# Altium schematic sheet Sheet2.SchDoc(Sheet2)
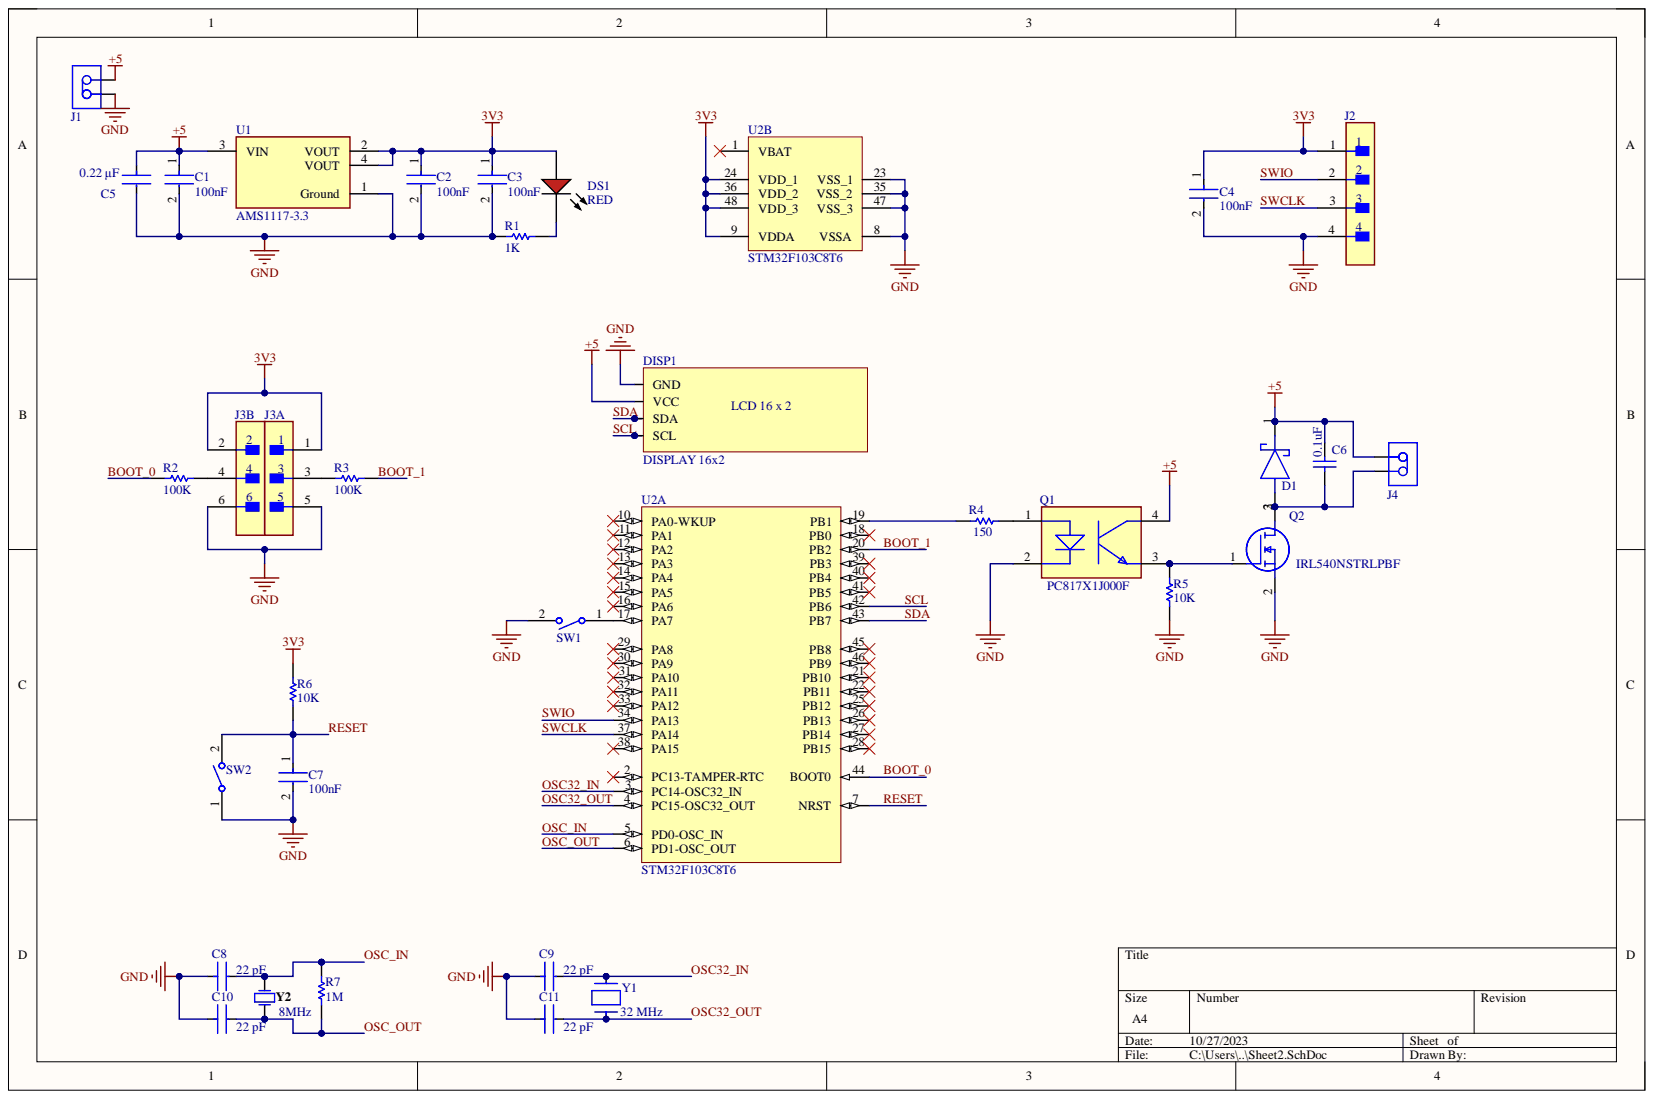
[diagram p0: Sheet2.SchDoc]

## Parts
C1  100nF  [FP-0805-L_2_01_0_2-W_1_25-IPC_B]
C2  100nF  [FP-0805-L_2_01_0_2-W_1_25-IPC_B]
C3  100nF  [FP-0805-L_2_01_0_2-W_1_25-IPC_B]
C4  100nF  [FP-0805-L_2_01_0_2-W_1_25-IPC_B]
C5  value 0.22 μF  Capacitance: 0.22 μF  [FP-C0603C-CF-MFG]  (hidden comment: C0603C224K3RACTU)
C6  0.1uF  [CAPR51-254-508X318X736]
C7  100nF  [FP-0805-L_2_01_0_2-W_1_25-IPC_B]
C8  value 22 pF  [FP-C0603C-CF-MFG]  (hidden comment: C0603C220J5GACTU)
C9  value 22 pF  [FP-C0603C-CF-MFG]  (hidden comment: C0603C220J5GACTU)
C10  value 22 pF  [FP-C0603C-CF-MFG]  (hidden comment: C0603C220J5GACTU)
C11  value 22 pF  [FP-C0603C-CF-MFG]  (hidden comment: C0603C220J5GACTU)
D1  SSB44-E3_52T  [DIOM5436X244N]  (value not drawn)
DISP1  DISPLAY 16x2  [display 16x2]
DS1  RED  [AVAG-HSMX-C190_V]
J1  640456-2  [TECO-640456-2_V]  (value not drawn)
J2  HTSW-104-07-G-S  [FP-HTSW-104-07-G-S-MFG]  (value not drawn)
J3  20021121-00006C4LF  [FP-20021121-00006C4LF-MFG]  (value not drawn)  (parts A,B)
J4  640456-2  [TECO-640456-2_V]  (value not drawn)
Q1  PC817X1J000F  [FP-PC817X1J000F-MFG]
Q2  IRL540NSTRLPBF  [IRF2804STRLPBF]
R1  1K  [RESC1608X55X30LL15T15]
R2  100K  [RESC2013X50X30ML20T20]
R3  100K  [RESC2013X50X30ML20T20]
R4  150  [RESC1608X55X25ML10T15]
R5  10K  [RESC1609X50X30NL8T20]
R6  10K  [RESC1609X50X30NL8T20]
R7  1M  [RESC1609X50X30LL10T20]
SW1  FSMSMTR  [TECO-FSMSMTR_V]  (value not drawn)
SW2  FSMSMTR  [TECO-FSMSMTR_V]  (value not drawn)
U1  AMS1117-3.3  [FP-AMS1117-IPC_C]
U2  STM32F103C8T6  [STM-LQFP48_L]  (parts A,B)
Y1  Operating Frequency: 32 MHz  [FP-ABM7-MFG]  (hidden comment: ABM7-32.000MHZ-D2Y-T)
Y2  Frequency: 8MHz  [FOX-HC49SDLF_V]  (hidden comment: FOXSDLF/080-20)

## Nets
3V3: C2.1 C3.1 C4.1 DS1.1 J2.1 J3.1 J3.2 R6.1 U1.2(VOUT) U1.4(VOUT) U2.9(VDDA) U2.24(VDD_1) U2.36(VDD_2) U2.48(VDD_3)
+5: C1.1 C5.1 C6.2 D1.1 DISP1.2(VCC) J1.1 J4.2 Q1.4 U1.3(VIN)
GND: C1.2 C2.2 C3.2 C4.2 C5.2 C7.2 C8.1 C9.1 C10.1 C11.1 DISP1.1(GND) J1.2 J2.4 J3.5 J3.6 Q1.2 Q2.2 R1.1 R5.2 SW1.2 SW2.1 U1.1(Ground) U2.8(VSSA) U2.23(VSS_1) U2.35(VSS_2) U2.47(VSS_3)
BOOT_0: R2.1 U2.44(BOOT0)
BOOT_1: R3.2 U2.20(PB2)
OSC32_IN: C9.2 U2.3(PC14-OSC32_IN) Y1.2
OSC32_OUT: C11.2 U2.4(PC15-OSC32_OUT) Y1.1
OSC_IN: C8.2 R7.2 U2.5(PD0-OSC_IN) Y2.2
OSC_OUT: C10.2 R7.1 U2.6(PD1-OSC_OUT) Y2.1
RESET: C7.1 R6.2 SW2.2 U2.7(NRST)
SCL: DISP1.4(SCL) U2.42(PB6)
SDA: DISP1.3(SDA) U2.43(PB7)
SWCLK: J2.3 U2.37(PA14)
SWIO: J2.2 U2.34(PA13)
NetC6_1: C6.1 D1.2 J4.1 Q2.3
NetDS1_2: DS1.2 R1.2
NetJ3_3: J3.3 R3.1
NetJ3_4: J3.4 R2.2
NetQ1_1: Q1.1 R4.2
NetQ1_3: Q1.3 Q2.1 R5.1
NetR4_1: R4.1 U2.19(PB1)
NetSW1_1: SW1.1 U2.17(PA7)

Not connected: U2 1(VBAT) 2(PC13-TAMPER-RTC) 10(PA0-WKUP) 11(PA1) 12(PA2) 13(PA3) 14(PA4) 15(PA5) 16(PA6) 18(PB0) 21(PB10) 22(PB11) 25(PB12) 26(PB13) 27(PB14) 28(PB15) 29(PA8) 30(PA9) 31(PA10) 32(PA11) 33(PA12) 38(PA15) 39(PB3) 40(PB4) 41(PB5) 45(PB8) 46(PB9)

## Library data of the parts
C1-C4 C7 = CMP-2007-04174-2: General Purpose Ceramic Capacitor, 0805, 100nF, 10%, X7R, 15%, 100V; Capacitance: 100nF; Case Code (Imperial): 0805; Case Code (Metric): 2012; Case/Package: 0805; Component Kind: Standard; Construction: Flat; Contact Plating: Tin; Depth: 1.25mm; Dielectric: X7R; Dielectric Material: Ceramic; Height: 1.4mm; Lead Free: Lead Free; Length: 2.01mm; Library Name: Altium Content Vault; Max Operating Temperature: 125°C; Min Operating Temperature: -55°C; Mount: Surface Mount; Number of Pins: 2; Package Quantity: 2000; Packaging: Tape and Reel; Radiation Hardening: No; REACH SVHC: No SVHC; RoHS Compliant: Yes; Series: -; Termination: SMD/SMT; Thickness: 0.055inch; Tolerance: 10%; Voltage: 100V; Voltage Rating: 100V; Voltage Rating (DC): 100V; Width: 0.049inch
C5 = CMP-2006-03146-2: CAP CER 0.22UF 25V 10% X7R 0603; Manufacturer: KEMET; Automotive Grade: Grade 1; Material: Ceramic; Temperature Coefficient: 30ppm/°C; Tolerance: 0.1; Automotive: Yes; Category: Cap; Component Kind: Standard; ComponentLink2Description: Datasheet; ComponentLink2URL: https://api.kemet.com/component-edge/download/datasheet/C0603C100J5GACAUTO.pdf; Device Class L1: Passive Components; Device Class L2: Capacitors; Device Class L3: Ceramic Capacitors; Digikey Description: CAP CER 0.22UF 25V 10% X7R 0603; Height: 0.87mm; Lead Free: Yes; Library Name: Altium Content Vault; Manufacturer Part Number: C0603C224K3RACTU; Mouser Description: Multilayer Ceramic Capacitors MLCC - SMD/SMT 25volts 0.22uF X7R 10%; Octopart: https://octopart.com/c0603c224k3ractu-kemet-129141; Package: C0603C-CF; RoHS: TRUE; Temperature: -55°C to +125°C; Temperature Characteristic: X7R; Temperature Range High: +125°C; Temperature Range Low: -55°C; Voltage Rating: 25 V
C6 = CMP-1664-00004-1: Radial Leaded Multilayer Ceramic Capacitor, 0.1 uF, +/- 10%, 50 V, -55 to 125 degC, 2-Pin THD, RoHS, Tape and Reel; Case\Package: SOT-23-5; Dropout Voltage: 260 mV; Max Input Voltage: 8 V; Max Power Dissipation: 250 mW; Output Current: 150 mA; Output Voltage: 5 V; Outputs: 1; Component Kind: Standard; ComponentLink1Description: Manufacturer URL; ComponentLink1URL: http://www.kemet.com/; ComponentLink2Description: Datasheet; ComponentLink2URL: http://www.kemet.com/docfinder?Partnumber=C320C104K5R5TA; DatasheetVersion: Dec-15; Height: 1.3 mm; Length: 3 mm; Library Name: Altium Content Vault; Max Operating Temperature: 85 degC; Min Operating Temperature: -40 degC; Mount: Surface Mount; Package Quantity: 3000; PackageDescription: 2-Pin Through Hole Device, Body 5.08 x 3.18 mm, Pitch 2.54 mm; PackageReference: CAPR51-254-508X318X736; Packaging: Tape and Reel; Pins: 5; Radiation Hardening: No; RoHSCompliant: true; Width: 1.8 mm
C8-C11 = CMP-2006-03119-3: Cap Ceramic 22pF 50V C0G 5% SMD 0603 125°C Paper T/R; Manufacturer: KEMET; Automotive Grade: Grade 1; Temperature Coefficient: 30ppm/°C; Tolerance: 0.05; Automotive: Yes; Capacitance: 22 pF; Category: Cap; Component Kind: Standard; ComponentLink2Description: Datasheet; ComponentLink2URL: https://api.kemet.com/component-edge/download/datasheet/C0603C100J5GACAUTO.pdf; Device Class L1: Passive Components; Device Class L2: Capacitors; Device Class L3: Ceramic Capacitors; Digikey Description: Cap Ceramic 22pF 50V C0G 5% SMD 0603 125°C Paper T/R; Height: 0.87mm; Lead Free: Yes; Library Name: Altium Content Vault; Manufacturer Part Number: C0603C220J5GACTU; Material: Ceramic; Mouser Description: Cap Ceramic 22pF 50V C0G 5% SMD 0603 125°C Paper T/R; Octopart: https://octopart.com/c0603c220j5gactu-kemet-98525; Package: C0603C-CF; RoHS: TRUE; Temperature: -55°C to +125°C; Temperature Characteristic: C0G; Temperature Range High: +125°C; Temperature Range Low: -55°C; Value: 22 pF; Voltage Rating: 50 V
D1 = SSB44-E3_52T: Schottky Diode; Manufacturer_Name: Vishay; Manufacturer_Part_Number: SSB44-E3/52T; Arrow Part Number: SSB44-E3/52T; Arrow Price/Stock: https://www.arrow.com/en/products/ssb44-e352t/vishay?region=nac; Component Kind: Standard; Datasheet Link: https://www.vishay.com/docs/88884/ssb43l.pdf; Height: 2.44mm; Mouser Part Number: 625-SSB44-E3; Mouser Price/Stock: https://www.mouser.co.uk/ProductDetail/Vishay-General-Semiconductor/SSB44-E3-52T?qs=x2jpVgRnAtOp%252BgpElPB95w%3D%3D
DS1 = CMP-1744-00004-1: LED Uni-Color Red, 65 mW, 20 mA, -40 to 85 degC, 2-Pin SMD, RoHS, Tape and Reel; Connector Type: USB; Current Rating: 1 A; Gender: Male; Component Kind: Standard; ComponentLink1Description: Manufacturer URL; ComponentLink1URL: http://www.avagotech.com/; ComponentLink2Description: Datasheet; ComponentLink2URL: http://docs.avagotech.com/docs/AV02-0551EN; ComponentLink3Description: Package Specification; ComponentLink3URL: http://www.fairchildsemi.com/dwg/MA/MA03D.pdf; Contact Material: Copper; Contact Plating: Gold; DatasheetVersion: Mar-12; Height: 2.8 mm; Lead Pitch: 0.65 mm; Length: 7.5 mm; Library Name: Altium Content Vault; Max Operating Temperature: 85 degC; Min Operating Temperature: -30 degC; Mount: Surface Mount; Package Quantity: 2000; PackageDescription: 2-Pin Surface Mount Device, Body 1.6 x 0.8 mm, Height 0.9 mm; PackageReference: HSMX-C190; Packaging: Tape and Reel; Pins: 5; REACH SVHC: No SVHC; RoHSCompliant: true; Width: 5.6 mm
J1 J4 = CMP-2000-05417-1: Male Header, Pitch 2.54 mm, 1 x 2 Position, Height 10.49 mm, Tail Length 3.556 mm, -55 to 105 degC, RoHS, Bulk; Manufacturer: TE Connectivity; Agency Approvals: CSA,UL; Angle: Straight; Brand/Series: MTA-100Series; Color, Housing: White; Connector Type: MTA-100; Contact Centerline Spacing: 0.100    in.; Contact Termination Area Plating: Tin; Current, Rating: 5    A; Material, Contact: CopperAlloy; Material, Housing: Polyester; Mount Angle: Vertical; Mounting Hole Size: 0.04    "; Number of Contacts: 2; Pin Spacing: 0.1    "; Primary Type: InterconnectSystem; Special Features: Pinstrip; Standards: RoHSCompliant,ELVCompliant,UL94V-0; Temperature, Operating: -55to+105    degC; Voltage, Rating: 250    V; Color: White; Component Kind: Standard; Contact Mating Area Plating: Tin; Contact Plating: Tin; Contact Shape: Square; Datasheet URL: https://datasheet.ciiva.com/11746/0900766b80eb7fdd-11746365.pdf; Datasheet Version: Rev. W, 06/2004; Flammability Rating: UL94V-0; Length, Overall: 0.2    "; Length, Pin: 0.14    "; Length, Terminal Post: 0.14    in.; Library Name: Altium Content Vault; Manufacturer URL: http://www.te.com/; Mounting Type: PCMount; Number of Positions: 2; Number of Rows: 1; Size, Post: 0.025    in.; Termination: Solder; Type: PCBPlug
J2 = CMP-02766-001776-1: CONN HEADER VERT 4POS 2.54MM; Gender: Male; Number of Contacts: 4; Component Kind: Standard; Contact Material: Bronze; Contact Plating: Gold; Library Name: Altium Content Vault; Mount: Through Hole; Number of Rows: 1; Packaging: Bulk; Pitch: 2.54mm; Radiation Hardening: No; Reach SVHC Compliant: Yes; RoHS Compliant: Yes; Series: HTSW; Termination: Through Hole
J3 = CMP-15126-126517163-1: 20021121-00006C4LF Conn Unshrouded Header HDR 6 POS 1.27mm Solder ST SMD Automotive T/R; Connector Type: Header, Male Pin; Contact Resistance: 30mΩ; Current Rating: 1A; Gender: Male; Insulation Resistance: 100MΩ; Mating Post Length: 3.05mm; Max Voltage Rating (AC): 125V; Number of Contacts: 6; Stack Height: 3mm; Color: Black; Component Kind: Standard; Contact Material: Copper, Gold; Contact Mating Length: 3.048mm; Contact Plating: Gold; Depth: 3.4mm; Height: 5.05mm; Housing Color: Black; Housing Material: Thermoplastic; Length: 3.81mm; Library Name: Altium Content Vault; Max Operating Temperature: 85°C; Min Operating Temperature: -55°C; Mount: Surface Mount; NexarPartId: 126517163; Number of Pins: 6; Number of Positions: 6; Number of Rows: 2; Orientation: Straight; Pitch: 1.27mm; Radiation Hardening: No; REACH SVHC: No SVHC; RoHS: Compliant; Row Spacing: 1.27mm; Termination: Solder; Voltage Rating: 125V
Q1 = CMP-65434-000003-1: OPTOISOLATOR 5KV TRANS 4DIP; Collector Emitter Breakdown Voltage: 80V; Collector Emitter Saturation Voltage: 200mV; Current Transfer Ratio: 600%; Fall Time: 18000ns; Forward Current: 50mA; Forward Voltage: 1.4V; Input Current: 20mA; Max Power Dissipation: 200mW; Number of Channels: 1; Reverse Breakdown Voltage: 6V; Case/Package: DIP; Collector Emitter Voltage (VCEO): 80V; Component Kind: Standard; Isolation Voltage: 5kV; Library Name: Altium Content Vault; Max Collector Current: 50mA; Max Input Current: 50mA; Max Operating Temperature: 100°C; Min Operating Temperature: -30°C; Mount: Through Hole; Number of Elements: 1; Number of Pins: 4; Output Current per Channel: 50mA; Output Voltage: 80V; Package Quantity: 2000; Packaging: Sleeve; Power Dissipation: 200mW; Radiation Hardening: No; Rise Time: 18000ns
Q2 = IRL540NSTRLPBF: MOSFET (N-Channel); Manufacturer_Name: Infineon; Arrow Part Number: IRL540NSTRLPBF; Arrow Price/Stock: https://www.arrow.com/en/products/irl540nstrlpbf/infineon-technologies-ag?region=nac; Component Kind: Standard; Datasheet Link: https://datasheet.datasheetarchive.com/originals/distributors/Datasheets_SAMA/a976ea931f47dfa9180662a8f907d205.pdf; Height: 4.83mm; Manufacturer_Part_Number: IRL540NSTRLPBF; Mouser Part Number: 942-IRL540NSTRLPBF; Mouser Price/Stock: https://www.mouser.co.uk/ProductDetail/Infineon-Technologies/IRL540NSTRLPBF?qs=9%252BKlkBgLFf2wqPOjL9rZ8Q%3D%3D
R1 = CMP-2000-00180-1: Manufacturer: Panasonic; Part Number: ERJ-3EKF1001V; Component Kind: Standard; Library Name: Altium Content Vault
R2 R3 = CMP-2001-04900-1: Manufacturer: Vishay Dale; Part Number: CRCW0805100KJNEA; Component Kind: Standard; Library Name: Altium Content Vault
R4 = CMP-1659-00057-1: Chip Resistor, 150 Ohm, +/- 1%, 0.1 W, -55 to 155 degC, 0603 (1608 Metric), RoHS, Tape and Reel; Case\Package: Radial; Forward Voltage: 2.2 V; Illumination Colour: Green; Lens Style: Clear; Luminous Intensity: 200 mcd; Viewing Angle: 20 degrees; Colour: Green; Component Kind: Standard; ComponentLink1Description: Manufacturer URL; ComponentLink1URL: http://www.yageo.com/; ComponentLink2Description: Datasheet; ComponentLink2URL: http://www.yageo.com/documents/recent/PYu-RC_Group_51_RoHS_L_04.pdf; DatasheetVersion: Rev. 4, 02/2016; Height: 8.6 mm; Library Name: Altium Content Vault; Mount: Through Hole; Nominal Vf: 2.2 V; Package Quantity: 1000; PackageDescription: 2-Pin Surface Mount Device, Body 1.6 x 0.8 mm; PackageReference: 0603; Packaging: Bulk; RoHSCompliant: true
R5 R6 = CMP-1666-00005-4: Chip Resistor, 10 KOhm, +/- 1%, 100 mW, -55 to 155 degC, 0603 (1608 Metric), RoHS, Tape and Reel; Manufacturer: Vishay Semiconductor; Voltage Rating: 75 V; Component Kind: Standard; ComponentLink1Description: Manufacturer URL; ComponentLink1URL: http://www.vishay.com/; ComponentLink2Description: Datasheet; ComponentLink2URL: https://datasheet.ciiva.com/11809/0900766b80d98afc-11809037.pdf; DatasheetVersion: 06/2012; Height: 0.45 mm; Length: 1.55 mm; Library Name: Altium Content Vault; Max Operating Temperature: 155 degC; Min Operating Temperature: -55 degC; Mounting Technology: Surface Mount; Package Quantity: 5000; PackageDescription: 2-Pin Surface Mount Device, Body 1.575 x 0.85 mm; PackageReference: 0603; Packaging: Tape and Reel; REACH SVHC: Unknown; RoHSCompliant: true
R7 = CMP-2000-03015-1: Manufacturer: Vishay Dale; Part Number: CRCW06031M00FKTA; Component Kind: Standard; Library Name: Altium Content Vault
SW1 SW2 = CMP-2000-07501-1: FSMSM Push Button Switch, 50 mA, -35 to 85 degC, 2-Pin SMD, RoHS, Tape and Reel; Manufacturer: TE Connectivity; Actuator Length: 1.07mm; Actuator Material: Polyamide; Case\/Package: SMD/SMT; Contact Current Rating: 50mA; Contact Resistance: 100mOhm; Electrical Life: 20000Cycles; Illumination Type: Illuminated; Max Voltage Rating (DC): 12V; Operating Force: 180gf; Switch Travel: 0.25mm; Throw Configuration: SPST; Voltage Rating (DC): 24V; Component Kind: Standard; Contact Material: Brass; Contact Plating: Silver; Current Rating: 50mA; Datasheet URL: http://www.te.com/commerce/DocumentDelivery/DDEController?Action=showdoc&DocId=Customer+Drawing%7F1437566-3%7FC2%7Fpdf%7FEnglish%7FENG_CD_1437566-3_C2_1437566_3_1_c.dwg.pdf%7F1437566-4; Datasheet Version: Rev. C2, 08/2014; ELV: Compliant; Lead Free: LeadFree; Library Name: Altium Content Vault; Manufacturer URL: http://www.te.com/; Max Operating Temperature: 85degC; Mechanical Life: 20000Cycles; Min Operating Temperature: -35degC; Mount: SurfaceMount; Mounting Technology: SurfaceMount; Orientation: Straight; Package Description: 2-Pin Surface Mount Device, Body 6 x 3.5 mm, Pitch 9.19 mm; Package Quantity: 1800; Package Reference: FSMSMTR; Packaging: TapeandReel; REACH SVHC: Unknown; RoHSCompliant: true; Termination: GullWing
U1 = CMP-209535-000001-1: LDO Voltage Regulators 1A, 3.3V; Manufacturer: Advanced Monolithic Systems; Dropout Voltage: 1.1V; Max Junction Temp: +125°C; Max Power Dissipation: 1.2W; Max Supply Voltage: 15V; Nominal Supply Current: 5mA; Number Of Outputs: 1; Output Type: Fixed; Standoff Height: 0.64mm; Automotive: No; Category: IC; Component Kind: Standard; ComponentLink2Description: Datasheet; ComponentLink2URL: http://www.advanced-monolithic.com/pdf/ds1117.pdf; Device Class L1: Integrated Circuits (ICs); Device Class L2: Power Management ICs; Device Class L3: Voltage Regulators - Linear; Height: 1.8mm; Ipc Land Pattern Name: SOT229P700X180-4; Lead Free: Yes; Library Name: Altium Content Vault; Manufacturer Part Number: AMS1117-3.3; Octopart: https://octopart.com/ams1117-3.3-advanced+monolithic+systems-25899300; Output Current: 1A; Output Voltage: 3.3V; Package: AMS1117; RoHS: TRUE; Temperature: -40°C to +125°C; Temperature Range High: +125°C; Temperature Range Low: -40°C
U2 = CMP-0237-00215-3: ARM Cortex-M3 32-bit MCU, 64 KB Flash, 20 KB Internal RAM, 37 I/Os, 48-pin LQFP, -40 to 85 degC, Tray; A/D Converter: 2x12-bit (10 Channel); FLASH Size (Prog) (kB): 64; I/Os (High Current): 37; Internal RAM Size (kB): 20; Operating Frequency(F) (Processor speed) (MHz): 72; Supply Voltage(Vcc) min (V): 2; Component Kind: Standard; ComponentLink1Description: Manufacturer URL; ComponentLink1URL: http://www.st.com/web/en/home.html; ComponentLink2Description: Datasheet; ComponentLink2URL: http://www.st.com/st-web-ui/static/active/en/resource/technical/document/datasheet/CD00161566.pdf; ComponentLink3Description: Package Specification; ComponentLink3URL: http://www.st.com/st-web-ui/static/active/en/resource/technical/document/datasheet/DM00058181.pdf; Core: ARM Cortex-M3; DatasheetVersion: Rev. 16; Library Name: Altium Content Vault; Mounting Technology: Surface Mount; PackageDescription: 48-Pin Low Profile Quad Flat Package, 7 x 7 mm Body, 0.5 mm Pitch; PackageReference: LQFP48; PackageVersion: Rev. 6, 06/2013; Packing: Tray; PartNumber: STM32F103C8T6
Y1 = CMP-27762-013665-1: Crystal 32MHz ±20ppm 18pF SMD-2 6mm x 3.5mm; ESR (Equivalent Series Resistance): 50R; Frequency Stability: 30ppm; Case/Package: CSMD; Component Kind: Standard; Depth: 3.5mm; Frequency: 32MHz; Frequency Tolerance: 20ppm; Height: 0.055inch; Lead Free: Lead Free; Length: 6mm; Library Name: Altium Content Vault; Load Capacitance: 18pF; Max Operating Temperature: 85°C; Min Operating Temperature: -40°C; Mount: Surface Mount; Number of Pins: 2; Package Quantity: 1000; Packaging: Tape and Reel; Radiation Hardening: No; Resistor Type: Ceramic; Series: ABM7; Series Resistance: 50R; Termination: SMD/SMT; Tolerance: 20ppm; Width: 3.3mm
Y2 = CMP-2100-03639-1: Resistance Weld Crystal, 8 MHz, +/- 30 ppm, -20 to 70 degC, 2-Pin SMD, RoHS, Tape and Reel; Manufacturer: FOX Electronics; ESR (Equivalent Series Resistance): 80Ohm; Frequency Stability: 50ppm; Frequency Tolerance: 30ppm; Load Capacitance: 20pF; Case/Package: SMD/SMT; Component Kind: Standard; Datasheet URL: http://www.foxonline.com/pdfs/hc49sdlf.pdf; Datasheet Version: 42064.0; Depth: 5mm; Height: 4.5mm; Lead Free: LeadFree; Length: 11.7mm; Library Name: Altium Content Vault; Manufacturer URL: http://www.foxonline.com/; Max Operating Temperature: 70degC; Min Operating Temperature: -20degC; Mount: SurfaceMount; Number of Pins: 2; Package Description: 2-Pin Surface Mount Device, Body 5 x 11.7 mm; Package Quantity: 1000; Package Reference: HC49SDLF; Packaging: TapeandReel; Radiation Hardening: No; REACH SVHC: NoSVHC; RoHSCompliant: True; Series Resistance: 80Ohm; Termination: SMD/SMT; Tolerance: 50ppm; Width: 5mm
DISP1 = DISPLAY 16x2: Component Kind: Standard; Library Name: Schlib1.SchLib
